# Altium schematic sheet 5_Interfaces.SchDoc
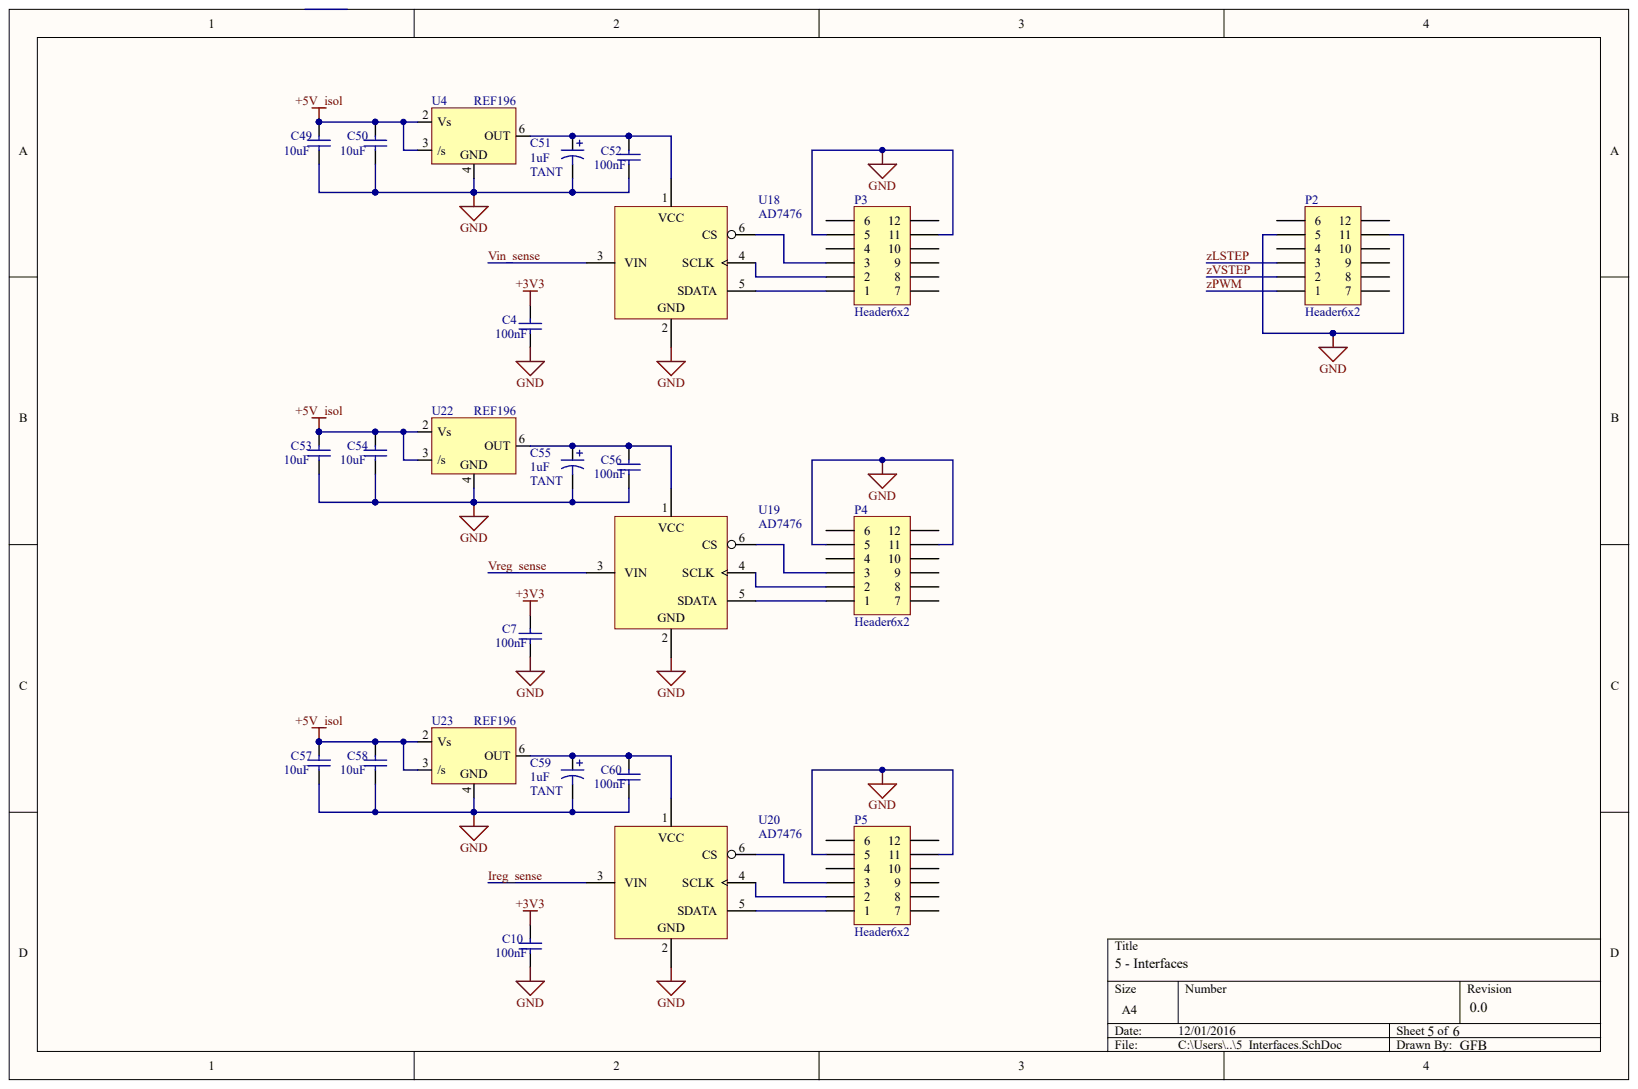
[diagram p0: 5_Interfaces.SchDoc]

## Parts
C4  value 100nF
C7  value 100nF
C10  value 100nF
C49  value 10uF
C50  value 10uF
C51  TANT  value 1uF
C52  value 100nF
C53  value 10uF
C54  value 10uF
C55  TANT  value 1uF
C56  value 100nF
C57  value 10uF
C58  value 10uF
C59  TANT  value 1uF
C60  value 100nF
P2  Header6x2
P3  Header6x2
P4  Header6x2
P5  Header6x2
U4  REF196
U18  AD7476
U19  AD7476
U20  AD7476
U22  REF196
U23  REF196

## Nets
+3V3: C4.2 C7.2 C10.2
+5V_ISOL: C49.2 C50.2 C53.2 C54.2 C57.2 C58.2 U4.2(Vs) U4.3(/s) U22.2(Vs) U22.3(/s) U23.2(Vs) U23.3(/s)
GND: C4.1 C7.1 C10.1 C49.1 C50.1 C51.2 C52.1 C53.1 C54.1 C55.2 C56.1 C57.1 C58.1 C59.2 C60.1 P2.5 P2.11 P3.5 P3.11 P4.5 P4.11 P5.5 P5.11 U4.4 U18.2 U19.2 U20.2 U22.4 U23.4
IREG_SENSE: U20.3(VIN)
VIN_SENSE: U18.3(VIN)
VREG_SENSE: U19.3(VIN)
ZLSTEP: P2.3
ZPWM: P2.1
ZVSTEP: P2.2
NetC51_1: C51.1 C52.2 U4.6(OUT) U18.1
NetC55_1: C55.1 C56.2 U19.1 U22.6(OUT)
NetC59_1: C59.1 C60.2 U20.1 U23.6(OUT)
NetP3_1: P3.1 U18.5(SDATA)
NetP3_2: P3.2 U18.4(SCLK)
NetP3_3: P3.3 U18.6(CS)
NetP4_1: P4.1 U19.5(SDATA)
NetP4_2: P4.2 U19.4(SCLK)
NetP4_3: P4.3 U19.6(CS)
NetP5_1: P5.1 U20.5(SDATA)
NetP5_2: P5.2 U20.4(SCLK)
NetP5_3: P5.3 U20.6(CS)
N00121:

Not connected: P2 4 6 7 8 9 10 12; P3 4 6 7 8 9 10 12; P4 4 6 7 8 9 10 12; P5 4 6 7 8 9 10 12

## Library data of the parts
P2-P5 = (no symbol name): Manufacturer: Molex Connector Corporation; Manufacturer Part Number: 90122-0766; Connector Type: Header, Unshrouded; Number of Positions: 12; Number of Positions Loaded: All; Pitch: 0.100" (2.54mm); Supplier 1: Digi-Key; RoHS: RoHS Compliant; Category: Connectors, Interconnects; Stock 1: 11; Pricing 1: 1=2.25, 10=2.041, 25=1.9164, 50=1.833, 100=1.7496, 250=1.583, 500=1.45802, 1000=1.24973 (EUR); Packaging: Bulk; Contact Type: Male Pin; Number of Rows: 2; Row Spacing: 0.100" (2.54mm); Contact Mating Length: 0.266" (6.75mm); Mounting Type: Through Hole, Right Angle; Termination: Solder; Fastening Type: -; Features: -; Contact Finish: Gold; Contact Finish Thickness: 15µin (0.38µm); Color: Black; ComponentLink1URL: http://www.molex.com/pdm_docs/sd/901220800_sd.pdf; ComponentLink1Description: http://www.molex.com/pdm_docs/sd/901220800_sd.pdf; Supplier Part Number 1: WM8179-ND; ClassName: zybo_conn
U18-U20 = (no symbol name): Manufacturer: ANALOG DEVICES; Manufacturer Part Number: AD7476ARTZ-500RL7; RS: 608-4717; Resolución (Bits): 12; Frecuencia de Muestreo: 1; Tensión de Alimentación: Simple; Tensión de Alimentación Mín.: 2.7; Tensión de Alimentación Máx.: 5.25; Modelo de ADC / DAC: SOT-23; Núm. de Contactos: 6; Canal de Entrada, Tipo: Terminación Única; Interfaz de Datos: Serie, SPI; Temperatura de Trabajo Mín.: -40; Temperatura de Trabajo Máx.: 85; Encapsulado: Cinta Cortada; Nivel de Sensibilidad a la Humedad (MSL): MSL 1 - Ilimitado; Sustancia Extremadamente Preocupante (SVHC): No SVHC (15-Jun-2015); Corriente de Alimentación: 3.5; Número de la Base: 7476; Rango de Temperatura de Trabajo: -40°C a +85; Rango de Tensión de Alimentación - Analógica: 2.35V a 5.25; Tensión de Alimentación, Rango: 2.7V a 5.25; Tipo de Terminación: Montaje Superficial (SMD)<!--FANDB--><div class="features-and-benefits-container"><div class="features-and-benefits-description"><span class="features-benefits-value">The AD7476ARTZ-500RL7 is a 1MSPS 12-bit High Speed Low Power Successive Approximation ADC that operates from a single 2.35 to 5.25V power supply and feature throughput rates up to 1MSPS. Each part contains a low noise, wide bandwidth track-and-hold amplifier that can handle input frequencies in excess of 6MHz. The conversion process and data acquisition are controlled using CS and the serial clock, allowing the devices to interface with microprocessors or DSPs. The input signal is sampled on the falling edge of CS and the conversion is initiated at this point. There are no pipeline delays associated with these parts. The AD7476 uses advanced design techniques to achieve very low power dissipation at high throughput rates. The reference for the parts is taken internally from VDD. This allows the widest dynamic input range to the ADC. Thus, the analogue input range for the parts is 0V to VDD. The conversion rate is determined by the SCLK.</span></div><div class="features-and-benefits-list"><ul class="features-and-benefits-list-list"><li class="features-and-benefits-list-item">Fast throughput rate</li><li class="features-and-benefits-list-item">Low power</li><li class="features-and-benefits-list-item">Wide input bandwidth</li><li class="features-and-benefits-list-item">Flexible power/serial clock speed management</li><li class="features-and-benefits-list-item">No pipeline delays</li><li class="features-and-benefits-list-item">High speed serial interface</li><li class="features-and-benefits-list-item">1; Supplier 1: Farnell; Supplier Part Number 1: 1651242; RoHS: YES; Stock 1: 475; Pricing 1: 1=10,44, 10=6,84, 100=5,57, 250=5,44, 500=5,29, 2000=5,15, 3000=5,02 (EUR); IC, Tipo: SOT-23; Núm. de Canales: 1; ComponentLink1URL: http://www.farnell.com/datasheets/1814854.pdf; ComponentLink1Description: http://www.farnell.com/datasheets/1814854.pdf; ComponentLink2URL: http://www.farnell.com/cad/1617094.zip; ComponentLink2Description: http://www.farnell.com/cad/1617094.zip; Supplier 2: RS; Supplier Part Number 2: 608-4717
C4 C7 C10 C49-C60 U4 U22 U23 = (no symbol name)
  C4 C7 C10 C49 C50 C52-C54 C56-C58 C60: Published: 8-Jun-2000; LatestRevisionDate: 17-Jul-2002; LatestRevisionNote: Re-released for DXP Platform.; PackageReference: RAD-0.3; PackageDescription: Radial Cap, Thru-Hole; 2 Leads; 0.3 in Pin Spacing
  C51 C55 C59: Published: 8-Jun-2000; LatestRevisionDate: 17-Jul-2002; LatestRevisionNote: Re-released for DXP Platform.; PackageReference: RB7.6-15; PackageDescription: Polarized Capacitor (Radial); 2 Leads; ClassName: Vstep
  C4 C7 C10 C49-C60: Publisher: Altium Limited
